# Altium schematic sheet USB-RS485_A2.SchDoc
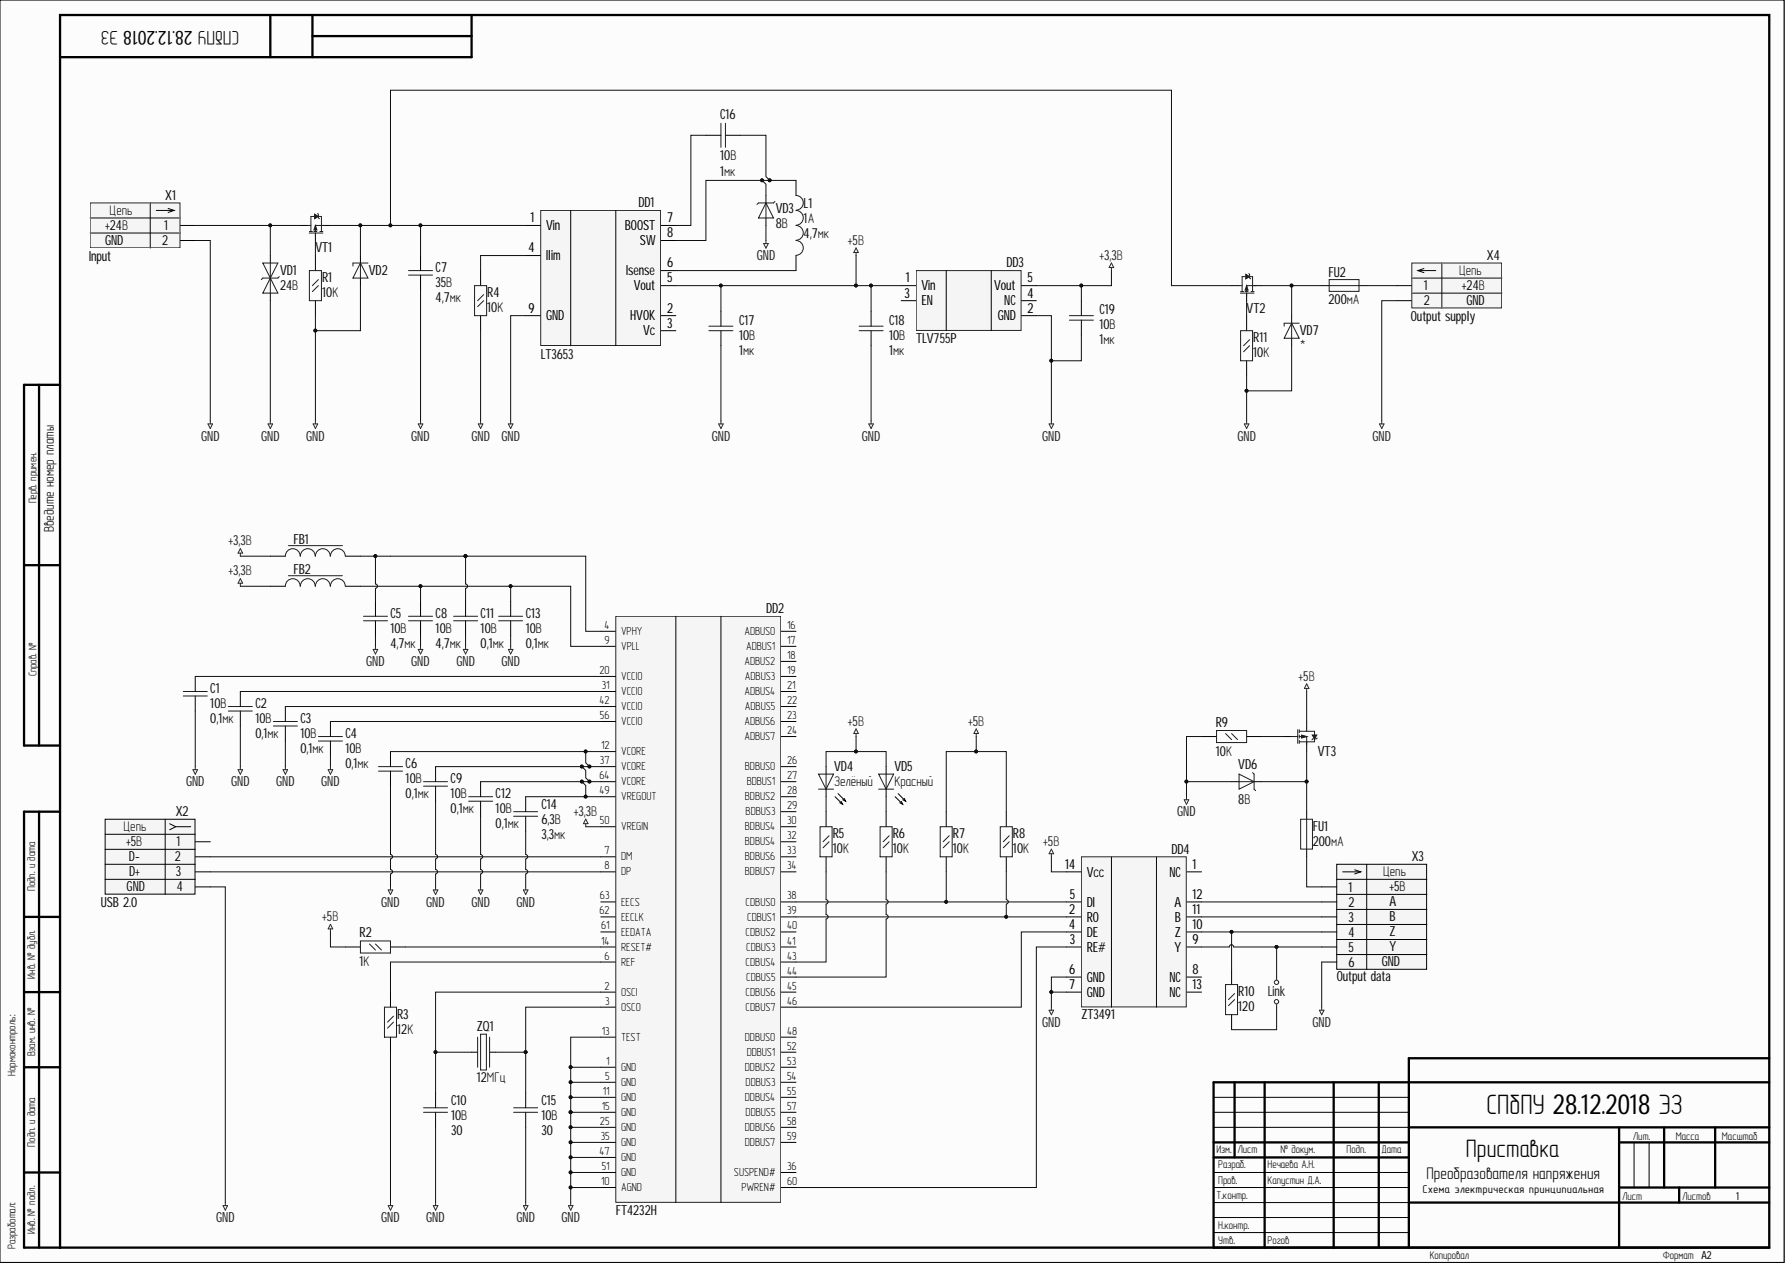
[diagram p0: USB-RS485_A2.SchDoc]

## Parts
C1  Voltage: 10В  [CH0402C]  (hidden comment: Capacitor)
C2  Capacitance: 0,1мк  Voltage: 10В  [CH0402C]  (hidden comment: Capacitor)
C3  Capacitance: 0,1мк  Voltage: 10В  [CH0402C]  (hidden comment: Capacitor)
C4  Capacitance: 0,1мк  Voltage: 10В  [CH0402C]  (hidden comment: Capacitor)
C5  Capacitance: 4,7мк  Voltage: 10В  [CH0402C]  (hidden comment: Capacitor)
C6  Voltage: 10В  [CH0402C]  (hidden comment: Capacitor)
C7  Capacitance: 4,7мк  Voltage: 35В  [CH0603C]  (hidden comment: Capacitor)
C8  Capacitance: 4,7мк  Voltage: 10В  [CH0402C]  (hidden comment: Capacitor)
C9  Capacitance: 0,1мк  Voltage: 10В  [CH0402C]  (hidden comment: Capacitor)
C10  Voltage: 10В  [CH0201C]  (hidden comment: Capacitor)
C11  Voltage: 10В  [CH0402C]  (hidden comment: Capacitor)
C12  Capacitance: 0,1мк  Voltage: 10В  [CH0402C]  (hidden comment: Capacitor)
C13  Capacitance: 0,1мк  Voltage: 10В  [CH0402C]  (hidden comment: Capacitor)
C14  Capacitance: 3,3мк  Voltage: 6,3В  [CH0402C]  (hidden comment: Capacitor)
C15  Voltage: 10В  [CH0201C]  (hidden comment: Capacitor)
C16  Capacitance: 1мк  Voltage: 10В  [CH0201C]  (hidden comment: Capacitor)
C17  Capacitance: 1мк  Voltage: 10В  [CH0201C]  (hidden comment: Capacitor)
C18  Capacitance: 1мк  [CH0201C]  (hidden comment: Capacitor)
C19  Capacitance: 1мк  Voltage: 10В  [CH0201C]  (hidden comment: Capacitor)
DD1  LT3653  [LT3653]
DD2  FT4232H  [FT4232]
DD3  TLV755P  [SOT23-5]
DD4  ZT3491  [ZT3485E]
FB1  Ferrite bead  [CH0805F]  (value not drawn)
FB2  Ferrite bead  [CH0805F]  (value not drawn)
FU1  value 200мА  [CH0402R]  (hidden comment: Fuse)
FU2  value 200мА  [CH0402R]  (hidden comment: Fuse)
L1  Inductance: 4,7мк  Max current: 1А  [CH0805L]  (hidden comment: Inductor)
R1  Impedance: 10К  [CH0402R]  (hidden comment: Res_0.125)
R2  Impedance: 1К  [CH0402R]  (hidden comment: Res_0.125)
R3  Impedance: 12К  [CH0805R]  (hidden comment: Res_0.125)
R4  Impedance: 10К  [CH0402R]  (hidden comment: Res_0.125)
R5  Impedance: 10К  [CH0402R]  (hidden comment: Res_0.125)
R6  Impedance: 10К  [CH0402R]  (hidden comment: Res_0.125)
R7  Impedance: 10К  [CH0402R]  (hidden comment: Res_0.125)
R8  Impedance: 10К  [CH0402R]  (hidden comment: Res_0.125)
R9  Impedance: 10К  [CH0402R]  (hidden comment: Res_0.125)
R10  Res_0.125  [CH0402R]  (value not drawn)
R11  Impedance: 10К  [CH0402R]  (hidden comment: Res_0.125)
VD1  Thresh_Voltage: 24В  [SOD323]  (hidden comment: TVS-diod_simmetrical)
VD2  Diod Zener  [SOD123]  (value not drawn)
VD3  Clamping_Voltage: 8В  [SOD123W]  (hidden comment: TVS-diod_directed)
VD4  Color: Зелёный  [CH0201L]  (hidden comment: Light_diod)
VD5  Color: Красный  [CH0201L]  (hidden comment: Light_diod)
VD6  Clamping_Voltage: 8В  [SOD123W]  (hidden comment: TVS-diod_directed)
VD7  Diod Zener  [SOD123]  (value not drawn)
VT1  P-Channel  [SOT23_132]  (value not drawn)
VT2  P-Channel  [SOT23_132]  (value not drawn)
VT3  (no value)  [SOT23_132]
X1  Input  Vcc: +24В  [CON: 163-179PH-EX]
X2  USB 2.0  Vcc: +5В  [USB]
X3  Output data  Vcc: +5В  [CON: PBS-6]
X4  Output supply  Vcc: +24В  [CON: PBS-2]
ZQ1  value 12МГц  [OSC: 5032]  (hidden comment: Oscillator)

## Nets
+3,3В: C19.1 DD2.50(VREGIN) DD3.5(Vout) FB1.1 FB2.1
+5В: C17.1 C18.1 DD1.5(Vout) DD3.1(Vin) DD4.14(Vcc) R2.2 R7.2 R8.2 VD4.1 VD5.1 VT3.2
GND: C1.2 C2.2 C3.2 C4.2 C5.2 C6.2 C7.2 C8.2 C9.2 C10.2 C11.2 C12.2 C13.2 C14.2 C15.2 C17.2 C18.2 C19.2 DD1.9(GND) DD2.1(GND) DD2.5(GND) DD2.10(AGND) DD2.11(GND) DD2.13(TEST) DD2.15(GND) DD2.25(GND) DD2.35(GND) DD2.47(GND) DD2.51(GND) DD3.2(GND) DD4.6(GND) DD4.7(GND) R1.1 R3.1 R4.1 R9.2 R11.1 VD1.1 VD2.1 VD3.1 VD6.1 VD7.1 X1.2 X2.4 X3.6 X4.2
Link: DD4.9(Y) R10.1 X3.5
NetC1_1: C1.1 DD2.20(VCCIO)
NetC2_1: C2.1 DD2.31(VCCIO)
NetC3_1: C3.1 DD2.42(VCCIO)
NetC4_1: C4.1 DD2.56(VCCIO)
NetC5_1: C5.1 C11.1 DD2.4(VPHY) FB1.2
NetC6_1: C6.1 C9.1 C12.1 C14.1 DD2.12(VCORE) DD2.37(VCORE) DD2.49(VREGOUT) DD2.64(VCORE)
NetC7_1: C7.1 DD1.1(Vin) VD2.2 VT1.3 VT2.2
NetC8_1: C8.1 C13.1 DD2.9(VPLL) FB2.2
NetC10_1: C10.1 DD2.2(OSCI) ZQ1.1
NetC15_1: C15.1 DD2.3(OSCO) ZQ1.3
NetC16_1: C16.1 DD1.7(BOOST)
NetC16_2: C16.2 DD1.8(SW) L1.1 VD3.2
NetDD1_4: DD1.4(Ilim) R4.2
NetDD1_6: DD1.6(Isense) L1.2
NetDD2_6: DD2.6(REF) R3.2
NetDD2_7: DD2.7(DM) X2.2
NetDD2_8: DD2.8(DP) X2.3
NetDD2_14: DD2.14(RESET#) R2.1
NetDD2_38: DD2.38(CDBUS0) DD4.5(DI) R7.1
NetDD2_39: DD2.39(CDBUS1) DD4.2(RO) R8.1
NetDD2_43: DD2.43(CDBUS4) R5.1
NetDD2_44: DD2.44(CDBUS5) R6.1
NetDD2_46: DD2.46(CDBUS7) DD4.4(DE)
NetDD2_60: DD2.60(PWREN#) DD4.3(RE#)
NetDD4_10: DD4.10(Z) R10.2 X3.4
NetDD4_11: DD4.11(B) X3.3
NetDD4_12: DD4.12(A) X3.2
NetFU1_1: FU1.1 X3.1
NetFU1_2: FU1.2 VD6.2 VT3.3
NetFU2_1: FU2.1 VD7.2 VT2.3
NetFU2_2: FU2.2 X4.1
NetR1_2: R1.2 VT1.1
NetR5_2: R5.2 VD4.2
NetR6_2: R6.2 VD5.2
NetR9_1: R9.1 VT3.1
NetR11_2: R11.2 VT2.1
NetVD1_2: VD1.2 VT1.2 X1.1

Not connected: DD1 2(HVOK) 3(Vc); DD2 16(ADBUS0) 17(ADBUS1) 18(ADBUS2) 19(ADBUS3) 21(ADBUS4) 22(ADBUS5) 23(ADBUS6) 24(ADBUS7) 26(BDBUS0) 27(BDBUS1) 28(BDBUS2) 29(BDBUS3) 30(BDBUS4) 32(BDBUS4) 33(BDBUS6) 34(BDBUS7) 36(SUSPEND#) 40(CDBUS2) 41(CDBUS3) 45(CDBUS6) 48(DDBUS0) 52(DDBUS1) 53(DDBUS2) 54(DDBUS3) 55(DDBUS4) 57(DDBUS5) 58(DDBUS6) 59(DDBUS7) 61(EEDATA) 62(EECLK) 63(EECS); DD3 3(EN) 4(NC); DD4 1(NC) 8(NC) 13(NC); X2 1

## Library data of the parts
C1 C6 C11 = Capacitor: Manufacture: AVX; Capacitance: 0,1мк; Model: 0402ZD103KAT2A; Case: 0402; Component Kind: Standard; HelpURL: https://ru.mouser.com/ProductDetail/AVX/0402ZD103KAT2A?qs=sGAEpiMZZMs0AnBnWHyRQKdiqyDPVQdAu74W%2fwwRq8A%3d; Library Name: GOST_Capacitors.SchLib
C2-C4 C9 C12 C13 = Capacitor: Manufacture: AVX; Model: 0402ZD103KAT2A; Case: 0402; Component Kind: Standard; HelpURL: https://ru.mouser.com/ProductDetail/AVX/0402ZD103KAT2A?qs=sGAEpiMZZMs0AnBnWHyRQKdiqyDPVQdAu74W%2fwwRq8A%3d; Library Name: GOST_Capacitors.SchLib
C5 C8 = Capacitor: Manufacture: Murata Electronics; Model: ZRB15XR61A475KE01D; Case: 0402; Component Kind: Standard; HelpURL: https://ru.mouser.com/ProductDetail/Murata-Electronics/ZRB15XR61A475KE01D?qs=sGAEpiMZZMs0AnBnWHyRQO9VYadaKTO%252beZnE9zFUsbv4FmCibz4ZfQ%3d%3d; Library Name: GOST_Capacitors.SchLib
C7 = Capacitor: Manufacture: TDK; Model: C1608JB1V475M080AC; Case: 0603; Component Kind: Standard; HelpURL: https://ru.mouser.com/ProductDetail/TDK/C1608JB1V475M080AC?qs=sGAEpiMZZMs0AnBnWHyRQFv7x1xn%252bYFd6VAPnwlpyGEmZrVGUJGxTQ%3d%3d; Library Name: GOST_Capacitors.SchLib
C10 C15 = Capacitor: Manufacture: KEMET; Capacitance: 30; Model: CBR02C308B8GAC; Case: 0201; Component Kind: Standard; HelpURL: https://ru.mouser.com/ProductDetail/KEMET/CBR02C308B8GAC?qs=sGAEpiMZZMs0AnBnWHyRQKJCfzG%2fi9wHnix1yOwfSPhOUzhoHh%252bTpQ%3d%3d; Library Name: GOST_Capacitors.SchLib
C14 = Capacitor: Manufacture: TDK; Model: C1005X5R0J335M050BC; Case: 0402; Component Kind: Standard; HelpURL: https://ru.mouser.com/ProductDetail/TDK/C1005X5R0J335M050BC?qs=sGAEpiMZZMs0AnBnWHyRQFzBYxg9rzNcvy5xMC7FxLU%3d; Library Name: GOST_Capacitors.SchLib
C16 C17 C19 = Capacitor: Manufacture: Murata Electronics; Model: GRM033C81A105ME05E; Case: 0201; Component Kind: Standard; HelpURL: https://ru.mouser.com/ProductDetail/Murata-Electronics/GRM033C81A105ME05E?qs=sGAEpiMZZMs0AnBnWHyRQN7%2fAA2D2lPPXfhIkJ3ChWM%252bNp28BKy3Ug%3d%3d; Library Name: GOST_Capacitors.SchLib
C18 = Capacitor: Manufacture: Murata Electronics; Model: GRM033C81A105ME05E; Voltage: 10В; Case: 0201; Component Kind: Standard; HelpURL: https://ru.mouser.com/ProductDetail/Murata-Electronics/GRM033C81A105ME05E?qs=sGAEpiMZZMs0AnBnWHyRQN7%2fAA2D2lPPXfhIkJ3ChWM%252bNp28BKy3Ug%3d%3d; Library Name: GOST_Capacitors.SchLib
DD1 = LT3653: 7.5~30 to 5; Component Kind: Standard; Model: LT3653; Library Name: GOST_lib_devices.SchLib
DD2 = FT4232H: Ann; Manufactere: FTDI, Future Technology Devices International Ltd; Case: QFN-64; Component Kind: Standard; HelpURL: https://www.ftdichip.com/Products/ICs/FT4232H.htm; Model: FT4232H; Library Name: GOST_lib_devices.SchLib
DD3 = LDO +3.3V: Manufacture: Texas Instruments; Case: SOT-23; Component Kind: Standard; HelpUrL: http://www.ti.com/product/TLV755P?keyMatch=TLV755P&tisearch=Search-EN-Everything; Model: TLV755P; Library Name: GOST_lib_devices.SchLib
DD4 = ZT3491: UART - RS485; Manufacture: Zywyn; Case: nSOIC-14; Component Kind: Standard; HelpURL: https://www.kynix.com/Detail/195117/ZT3483LEEN.html; Model: ZT3491; Library Name: GOST_lib_devices.SchLib
FB1 FB2 = Ferrite bead: Manufacture: Laird; Impedance: 40Ом; Max DC current: 1,5А; Model: MI0805K400R-10; Case: 0805; Component Kind: Standard; HekpURL: https://www2.mouser.com/ProductDetail/Laird/MI0805K400R-10?qs=%2fha2pyFaduiHND%252bdjhHwYezPllDM7l6y0ARhTY4M0iGJeUtz%252byGA0A%3d%3d; Library Name: GOST_Inductors.SchLib
L1 = Inductor: Manufacture: Panasonic; Impedance: 300м; Model: ELG-TEA4R7NA; Case: 0805; Component Kind: Standard; HelpURL: https://ru.mouser.com/ProductDetail/Panasonic/ELG-TEA4R7NA?qs=sGAEpiMZZMsg%252by3WlYCkU%252bdHxGw%252bjF29VUqcX1cNOgM%3d; Library Name: GOST_Inductors.SchLib
R1 R4-R9 R11 = Res_0.125: Manufacture: Yageo; Model: AC0402FR-7W10KL; Case: 0402; Component Kind: Standard; HelpURL: https://ru.mouser.com/ProductDetail/Yageo/AC0402FR-7W10KL?qs=sGAEpiMZZMvdGkrng054txbN%2f5LBcxld3gyqgmBuiXRPLjwmdNqO3A%3d%3d; Library Name: GOST_Resistors.SchLib
R2 = Res_0.125: Manufacture: KOA Speer; Model: SG73P1ETTP1001F; Case: 0402; Component Kind: Standard; HelpURL: https://ru.mouser.com/ProductDetail/KOA-Speer/SG73P1ETTP1001F?qs=sGAEpiMZZMvdGkrng054t%2f16WM9J8YovCYtiWkW5%2faA%3d; Library Name: GOST_Resistors.SchLib
R3 = Res_0.125: Manufacture: Panasonic; Model: ERJ-6GEYK126V; Case: 0805; Component Kind: Standard; HelpURL: https://ru.mouser.com/ProductDetail/Panasonic/ERJ-6GEYK126V?qs=sGAEpiMZZMvdGkrng054t67Efho1F8r6k%252bzuIF5xRYA%3d; Library Name: GOST_Resistors.SchLib
R10 = Res_0.125: Manufacture: KOA Speer; Impedance: 120; Model: SG73S1ETTP121J; Case: 0402; Component Kind: Standard; HelpURL: https://ru.mouser.com/ProductDetail/KOA-Speer/SG73S1ETTP121J?qs=sGAEpiMZZMvdGkrng054t%2f16WM9J8YovbyvN2zYhrU8%3d; Library Name: GOST_Resistors.SchLib
VD1 = TVS-diod_simmetrical: Manufacturer: Torex Semiconductor; Model: XBP1012-G; Case: SOD-323P; Component Kind: Standard; HelpURL: https://ru.mouser.com/Semiconductors/Discrete-Semiconductors/Diodes-Rectifiers/TVS-Diodes-ESD-Suppressors/_/N-azknd?P=1z0z7ymZ1yzv44xZ1y95zfoZ1z0x69g&Ns=Package+%2f+Case%7c0; Library Name: GOST_Diodes.SchLib
VD3 VD6 = TVS-diod_directed: Manufacturer: Nexperia; Model: PTVS3V3S1UTR,115; Case: SOD-123W; Component Kind: Standard; HelpURL: https://ru.mouser.com/ProductDetail/Nexperia/PTVS3V3S1UTR115?qs=sGAEpiMZZMsItbzKdwyIe55sLWjhUV0FnMtsDZGUovc%3d; Library Name: GOST_Diodes.SchLib
VD4 = Light_diod: Manufacture: Kingbright; If: 10мА; Model: APG0603CGC-TT; Thresh_Voltage: 1,97В; Component Kind: Standard; HelpURL: https://ru.mouser.com/ProductDetail/Kingbright/APG0603CGC-TT?qs=sGAEpiMZZMseGfSY3csMkWhaTYyynk7zmJSHZVA83x%252bt%2FzMFAtnBag%3D%3D; Library Name: GOST_Diodes.SchLib
VD5 = Light_diod: Manufacture: Kingbright; If: 10мА; Model: APG0603SURC-TT; Thresh_Voltage: 1,97В; Component Kind: Standard; HelpURL: https://ru.mouser.com/ProductDetail/Kingbright/APG0603SURC-TT?qs=sGAEpiMZZMseGfSY3csMkWhaTYyynk7zWfpYoqHc%252biGwdyFqmyeODA%3D%3D; Library Name: GOST_Diodes.SchLib
VT1 VT2 = P-Channel: Manufacture: Diodes Incorporated; Id: 3,4А; Model: DMP4065S-7; Vgs: 1В; Vsd: 40В; Component Kind: Standard; HelpURL: https://ru.mouser.com/ProductDetail/Diodes-Incorporated/DMP4065S-7?qs=sGAEpiMZZMshyDBzk1%2fWizUdW5KNcF83uXTduzoNpXIy82%252bBOlvohQ%3d%3d; Library Name: GOST_Transistors.SchLib
VT3 = P-Channel: Manufacture: Vishay / Siliconix; Id: 6А; Model: SI2329DS-T1-GE3; Vgs: 350мВ; Vsd: 8В; Component Kind: Standard; HelpURL: https://ru.mouser.com/ProductDetail/Vishay-Siliconix/SI2329DS-T1-GE3?qs=sGAEpiMZZMshyDBzk1%2fWi0IfsQ3%2f3bgnEGeh9AiMdAo%3d; Library Name: GOST_Transistors.SchLib
X1 X4 = 2_pins: Component Kind: Standard; Library Name: GOST_lib_connectors.SchLib
  X1: HelpURL: https://ru.farnell.com/gct-global-connector-technology/dcj250-10-a-k1-k/dc-power-jack-5a-20vdc-black/dp/2524130?st=power%20jack
  X4: HelpURL: https://ru.mouser.com/ProductDetail/Harwin/M50-3140245R?qs=sGAEpiMZZMs%252bGHln7q6pm8Vn94ktop%2FJtmD0IM0sqjtMooR%2Fqw0FIA%3D%3D
X2 = USB-A: USB; Manufacturer: TE Connectivity; Model: 292303-2; Component Kind: Standard; ComponentKind: type A; HelpURL: https://www2.mouser.com/ProductDetail/Wurth-Electronics/629004113921?qs=35zkduByVmhHRkVkRjAlEQ==; Library Name: Connectors.SchLib
X3 = Full_Duplex_RS485: A: A; B: B; Component Kind: Standard; HelpURL: https://ru.mouser.com/ProductDetail/Harwin/M50-3140645?qs=sGAEpiMZZMs%252bGHln7q6pm8Vn94ktop%2FJjOh82Azijbg9ipiItTiXHg%3D%3D; Y: Y; Z: Z; Library Name: GOST_lib_connectors.SchLib
ZQ1 = Oscillator: Manufacture: CTS Electronic Components; Component Kind: Standard; HelpURL: https://ru.mouser.com/ProductDetail/CTS-Electronic-Components/636L3I012M00000?qs=sGAEpiMZZMtldj7qu1ydrWzf7LKZ2EliIlXd3ltxwts%3d; Model: https://ru.mouser.com/ProductDetail/CTS-Electronic-Components/636L3I012M00000?qs=sGAEpiMZZMtldj7qu1ydrWzf7LKZ2EliIlXd3ltxwts%3d; Library Name: GOST_Miscelanuious.SchLib
FU1 FU2 = Fuse: Component Kind: Standard; HelpURL: https://www2.mouser.com/ProductDetail/Bourns/SF-0402FP020F-2?qs=sGAEpiMZZMtxU2g%2f1juGqWJziY9CkRY0v%252bd32oCrRTr%2fW8XqdHGjQA%3d%3d; Library Name: GOST_Miscelanuious.SchLib
VD2 VD7 = Diod Zener: Component Kind: Standard; Library Name: GOST_Diodes.SchLib
